# Altium schematic sheet Sensores.SchDoc(Sensores)
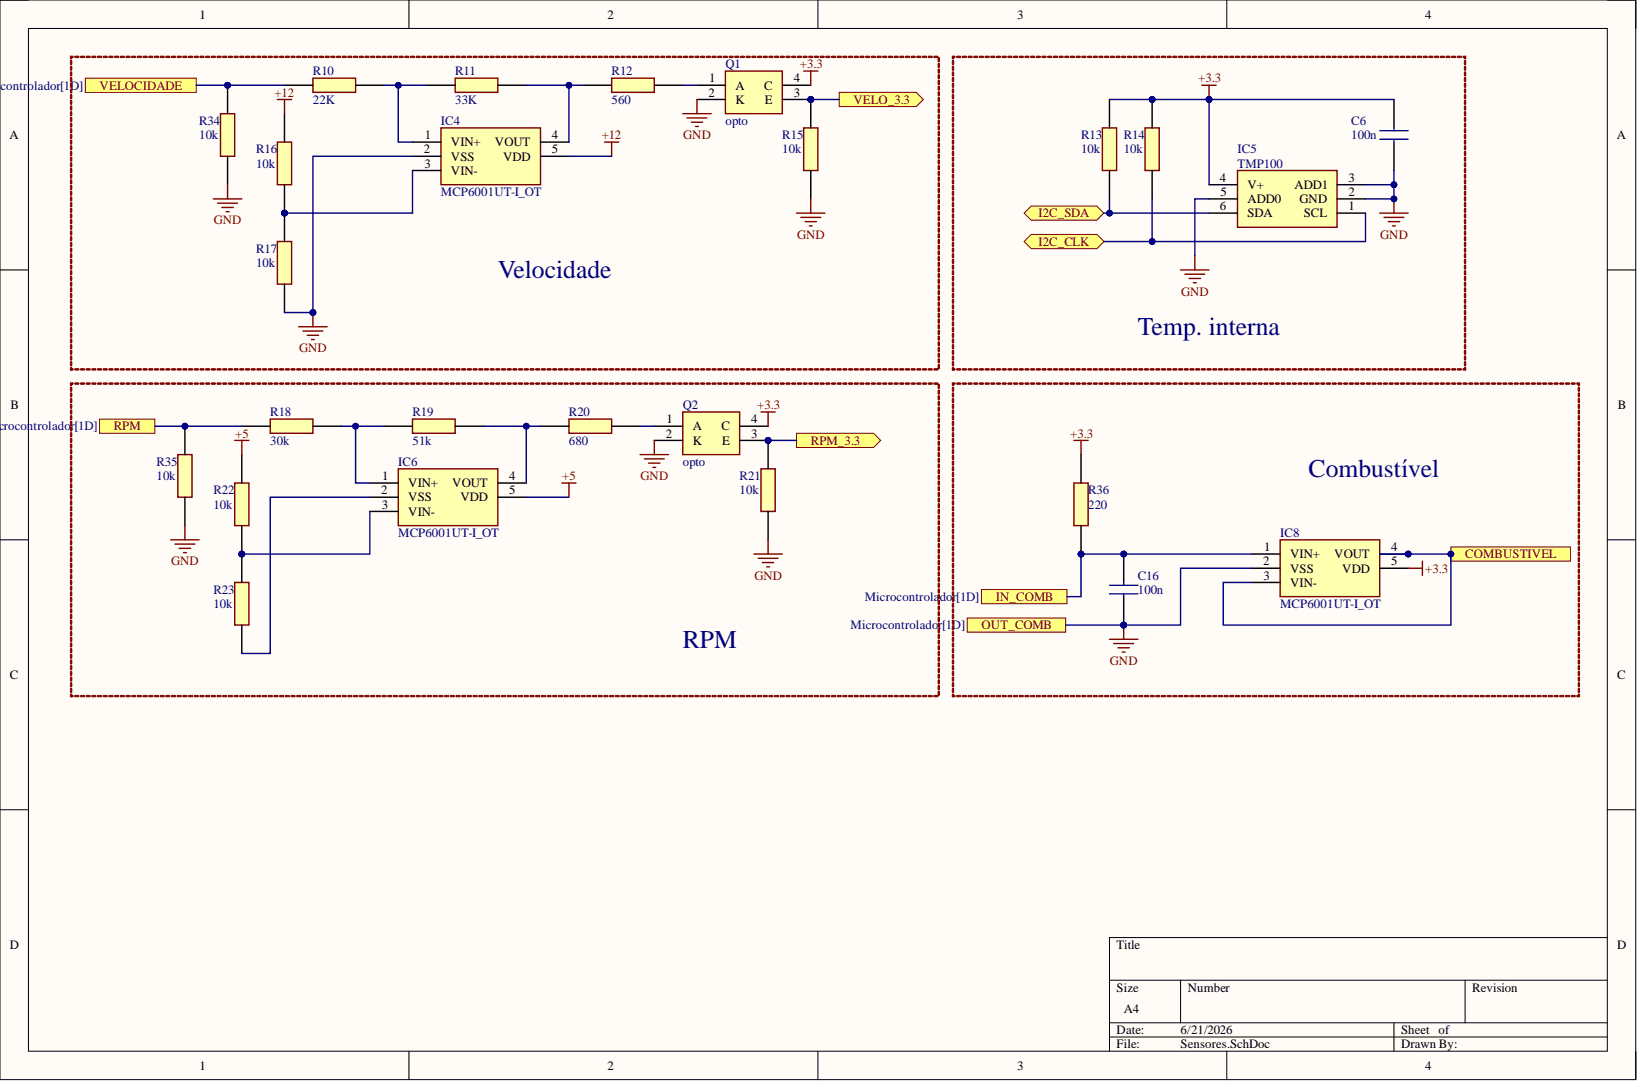
[diagram p0: Sensores.SchDoc]

## Parts
C6  100n
C16  100n
IC4  MCP6001UT-I_OT
IC5  TMP100
IC6  MCP6001UT-I_OT
IC8  MCP6001UT-I_OT
Q1  opto
Q2  opto
R10  22K
R11  33K
R12  560
R13  10k
R14  10k
R15  10k
R16  10k
R17  10k
R18  30k
R19  51k
R20  680
R21  10k
R22  10k
R23  10k
R34  10k
R35  10k
R36  220

## Ports
COMBUSTIVEL, I2C_CLK, I2C_SDA, IN_COMB, OUT_COMB, RPM, RPM_3.3, VELO_3.3, VELOCIDADE

## Nets
+3.3: C6.2 IC5.4(V+) IC8.5(VDD) Q1.4(C) Q2.4(C) R13.1 R14.1 R36.2
+5: IC6.5(VDD) R22.1
+12: IC4.5(VDD) R16.1
COMBUSTIVEL: IC8.3(VIN-) IC8.4(VOUT) ; port COMBUSTIVEL
GND: C6.1 C16.1 IC4.2(VSS) IC5.2(GND) IC5.3(ADD1) IC5.5(ADD0) IC8.2(VSS) Q1.2(K) Q2.2(K) R15.2 R17.2 R21.2 R34.2 R35.2 ; port OUT_COMB
I2C_CLK: IC5.1(SCL) R14.2 ; port I2C_CLK
I2C_SDA: IC5.6(SDA) R13.2 ; port I2C_SDA
IN_COMB: C16.2 IC8.1(VIN+) R36.1 ; port IN_COMB
RPM: R18.1 R35.1 ; port RPM
RPM_3.3: Q2.3(E) R21.1 ; port RPM_3.3
VELO_3.3: Q1.3(E) R15.1 ; port VELO_3.3
VELOCIDADE: R10.1 R34.1 ; port VELOCIDADE
NetIC4_1: IC4.1(VIN+) R10.2 R11.1
NetIC4_3: IC4.3(VIN-) R16.2 R17.1
NetIC4_4: IC4.4(VOUT) R11.2 R12.1
NetIC6_1: IC6.1(VIN+) R18.2 R19.1
NetIC6_2: IC6.2(VSS) R23.2
NetIC6_3: IC6.3(VIN-) R22.2 R23.1
NetIC6_4: IC6.4(VOUT) R19.2 R20.1
NetQ1_1: Q1.1(A) R12.2
NetQ2_1: Q2.1(A) R20.2

## Library data of the parts
C6 C16 = CL21B104KBCNNNC: Capacitor; Manufacturer_Name: SAMSUNG; Arrow Part Number: CL21B104KBCNNNC; Arrow Price/Stock: https://www.arrow.com/en/products/cl21b104kbcnnnc/samsung-electro-mechanics?region=europe; Component Kind: Standard; Datasheet Link: https://datasheet.datasheetarchive.com/originals/distributors/Datasheets_SAMA/86a3eaf0882763ef32124d5901f9b833.pdf; Height: 0.95mm; Manufacturer_Part_Number: CL21B104KBCNNNC; Mouser Part Number: 187-CL21B104KBCNNNC; Mouser Price/Stock: https://www.mouser.co.uk/ProductDetail/Samsung-Electro-Mechanics/CL21B104KBCNNNC?qs=349EhDEZ59pEfpnm5yBEmQ%3D%3D
IC4 IC6 IC8 = MCP6001UT-I_OT: Integrated Circuit; Manufacturer_Name: Microchip; Manufacturer_Part_Number: MCP6001UT-I/OT; Arrow Part Number: MCP6001UT-I/OT; Arrow Price/Stock: https://www.arrow.com/en/products/mcp6001ut-iot/microchip-technology?utm_currency=USD&region=nac; Component Kind: Standard; Datasheet Link: http://componentsearchengine.com/Datasheets/3/MCP6001UT-I_OT.pdf; Height: 1.45mm; Mouser Part Number: 579-MCP6001UT-I/OT; Mouser Price/Stock: https://www.mouser.co.uk/ProductDetail/Microchip-Technology/MCP6001UT-I-OT?qs=Sez7gRs8XSU2iw24kVuIOw%3D%3D
  IC4 IC6: Library Name: SamacSys.SchLib
IC5 = TMP100NA_3K: Integrated Circuit; Manufacturer_Name: Texas Instruments; Manufacturer_Part_Number: TMP100NA/3K; Arrow Part Number: TMP100NA/3K; Arrow Price/Stock: https://www.arrow.com/en/products/tmp100na3k/texas-instruments?utm_currency=USD&region=europe; Component Kind: Standard; Datasheet Link: https://www.ti.com/lit/gpn/TMP100; Height: 1.45mm; Mouser Part Number: 595-TMP100NA/3K; Mouser Price/Stock: https://www.mouser.co.uk/ProductDetail/Texas-Instruments/TMP100NA-3K?qs=RnzODY3cU8ttwUxnXA%252BgRQ%3D%3D
Q1 Q2 = EL817_S1__C__TU_: Phototransistor; Manufacturer_Name: Everlight; Manufacturer_Part_Number: EL817(S1)(C)(TU); Arrow Part Number: EL817(S1)(C)(TU); Arrow Price/Stock: https://www.arrow.com/en/products/el817s1-c-tu/everlight-electronics?utm_currency=USD&region=europe; Component Kind: Standard; Datasheet Link: https://eu.mouser.com/datasheet/2/143/201407061833256242-365628.pdf; Height: 3.9mm; Mouser Part Number: 638-EL817S1CTU; Mouser Price/Stock: https://www.mouser.co.uk/ProductDetail/Everlight/EL817S1CTU?qs=8PzhAHr7IdNLRo4JydPHmQ%3D%3D
R10 = ERA-6ARW223V: Resistor; Manufacturer_Name: Panasonic; Component Kind: Standard; Datasheet Link: https://industrial.panasonic.com/cdbs/www-data/pdf/RDM0000/AOA0000C307.pdf; Height: 0.6mm; Library Name: SamacSys.SchLib; Manufacturer_Part_Number: ERA-6ARW223V; Mouser Part Number: 667-ERA-6ARW223V; Mouser Price/Stock: https://www.mouser.co.uk/ProductDetail/Panasonic/ERA-6ARW223V/?qs=ob%252BdNz2%252BYEhh9t5AnW%252B42Q%3D%3D
R11 = ERA-6APB333V: Resistor; Manufacturer_Name: Panasonic; Component Kind: Standard; Datasheet Link: https://industrial.panasonic.com/cdbs/www-data/pdf/RDM0000/AOA0000C307.pdf; Height: 0.6mm; Library Name: SamacSys.SchLib; Manufacturer_Part_Number: ERA-6APB333V; Mouser Part Number: 667-ERA-6APB333V; Mouser Price/Stock: https://www.mouser.com/Search/Refine.aspx?Keyword=667-ERA-6APB333V
R12 = RC0805FR-07560RL: Resistor; Manufacturer_Name: KEMET; Arrow Part Number: RC0805FR-07560RL; Arrow Price/Stock: https://www.arrow.com/en/products/rc0805fr-07560rl/yageo?region=nac; Component Kind: Standard; Datasheet Link: http://www.yageo.com/documents/recent/PYu-RC_Group_51_RoHS_L_10.pdf; Height: 0.6mm; Library Name: SamacSys.SchLib; Manufacturer_Part_Number: RC0805FR-07560RL; Mouser Part Number: 603-RC0805FR-07560RL; Mouser Price/Stock: https://www.mouser.co.uk/ProductDetail/YAGEO/RC0805FR-07560RL?qs=g6xwsc4j%252B%2Foqaguphwrcog%3D%3D
R13-R17 R21-R23 R34 R35 = RC0805FR-0710KL: Resistor; Manufacturer_Name: YAGEO; Arrow Part Number: RC0805FR-0710KL; Arrow Price/Stock: https://www.arrow.com/en/products/rc0805fr-0710kl/yageo?utm_currency=USD&region=europe; Component Kind: Standard; Datasheet Link: https://www.yageo.com/upload/media/product/productsearch/datasheet/rchip/PYu-RC_Group_51_RoHS_L_11.pdf; Height: 0.6mm; Manufacturer_Part_Number: RC0805FR-0710KL; Mouser Part Number: 603-RC0805FR-0710KL; Mouser Price/Stock: https://www.mouser.co.uk/ProductDetail/YAGEO/RC0805FR-0710KL?qs=7bY8808IzHq6vrU3BeiiYw%3D%3D
R18 = RT0805BRD0730KL: Resistor; Manufacturer_Name: KEMET; Arrow Part Number: RT0805BRD0730KL; Arrow Price/Stock: https://www.arrow.com/en/products/rt0805brd0730kl/yageo; Component Kind: Standard; Datasheet Link: https://componentsearchengine.com/Datasheets/2/RT0805BRD0730KL.pdf; Height: 0.6mm; Manufacturer_Part_Number: RT0805BRD0730KL; Mouser Part Number: 603-RT0805BRD0730KL; Mouser Price/Stock: https://www.mouser.com/Search/Refine.aspx?Keyword=603-RT0805BRD0730KL
R19 = RT0805BRD0751KL: Resistor; Manufacturer_Name: YAGEO; Component Kind: Standard; Datasheet Link: https://www.yageo.com/upload/media/product/productsearch/datasheet/rchip/PYu-RT_1-to-0.01_RoHS_L_13.pdf; Height: 0.6mm; Manufacturer_Part_Number: RT0805BRD0751KL; Mouser Part Number: 603-RT0805BRD0751KL; Mouser Price/Stock: https://www.mouser.co.uk/ProductDetail/YAGEO/RT0805BRD0751KL?qs=aZCcseWLxnvRd%252Bt7WtqU%252BQ%3D%3D
R20 = RC0805FR-07680RL: Resistor; Manufacturer_Name: KEMET; Component Kind: Standard; Datasheet Link: http://www.yageo.com/documents/recent/PYu-RC_Group_51_RoHS_L_10.pdf; Height: 0.6mm; Manufacturer_Part_Number: RC0805FR-07680RL; Mouser Part Number: 603-RC0805FR-07680RL; Mouser Price/Stock: https://www.mouser.co.uk/ProductDetail/Yageo/RC0805FR-07680RL/?qs=g6xwsc4j%252B%2FokQfkwsK2vhQ%3D%3D
R36 = ERA-6VEB2200V: Resistor; Manufacturer_Name: Panasonic; Arrow Part Number: ERA-6VEB2200V; Arrow Price/Stock: https://www.arrow.com/en/products/era-6veb2200v/panasonic?region=nac; Component Kind: Standard; Datasheet Link: http://industrial.panasonic.com/cdbs/www-data/pdf/RDM0000/RDM0000C331.pdf; Height: 0.65mm; Manufacturer_Part_Number: ERA-6VEB2200V; Mouser Part Number: 667-ERA-6VEB2200V; Mouser Price/Stock: https://www.mouser.co.uk/ProductDetail/Panasonic/ERA-6VEB2200V?qs=rI7uf1IzohSY2pbvttNgkw%3D%3D
